# Altium schematic sheet Probe.SchDoc
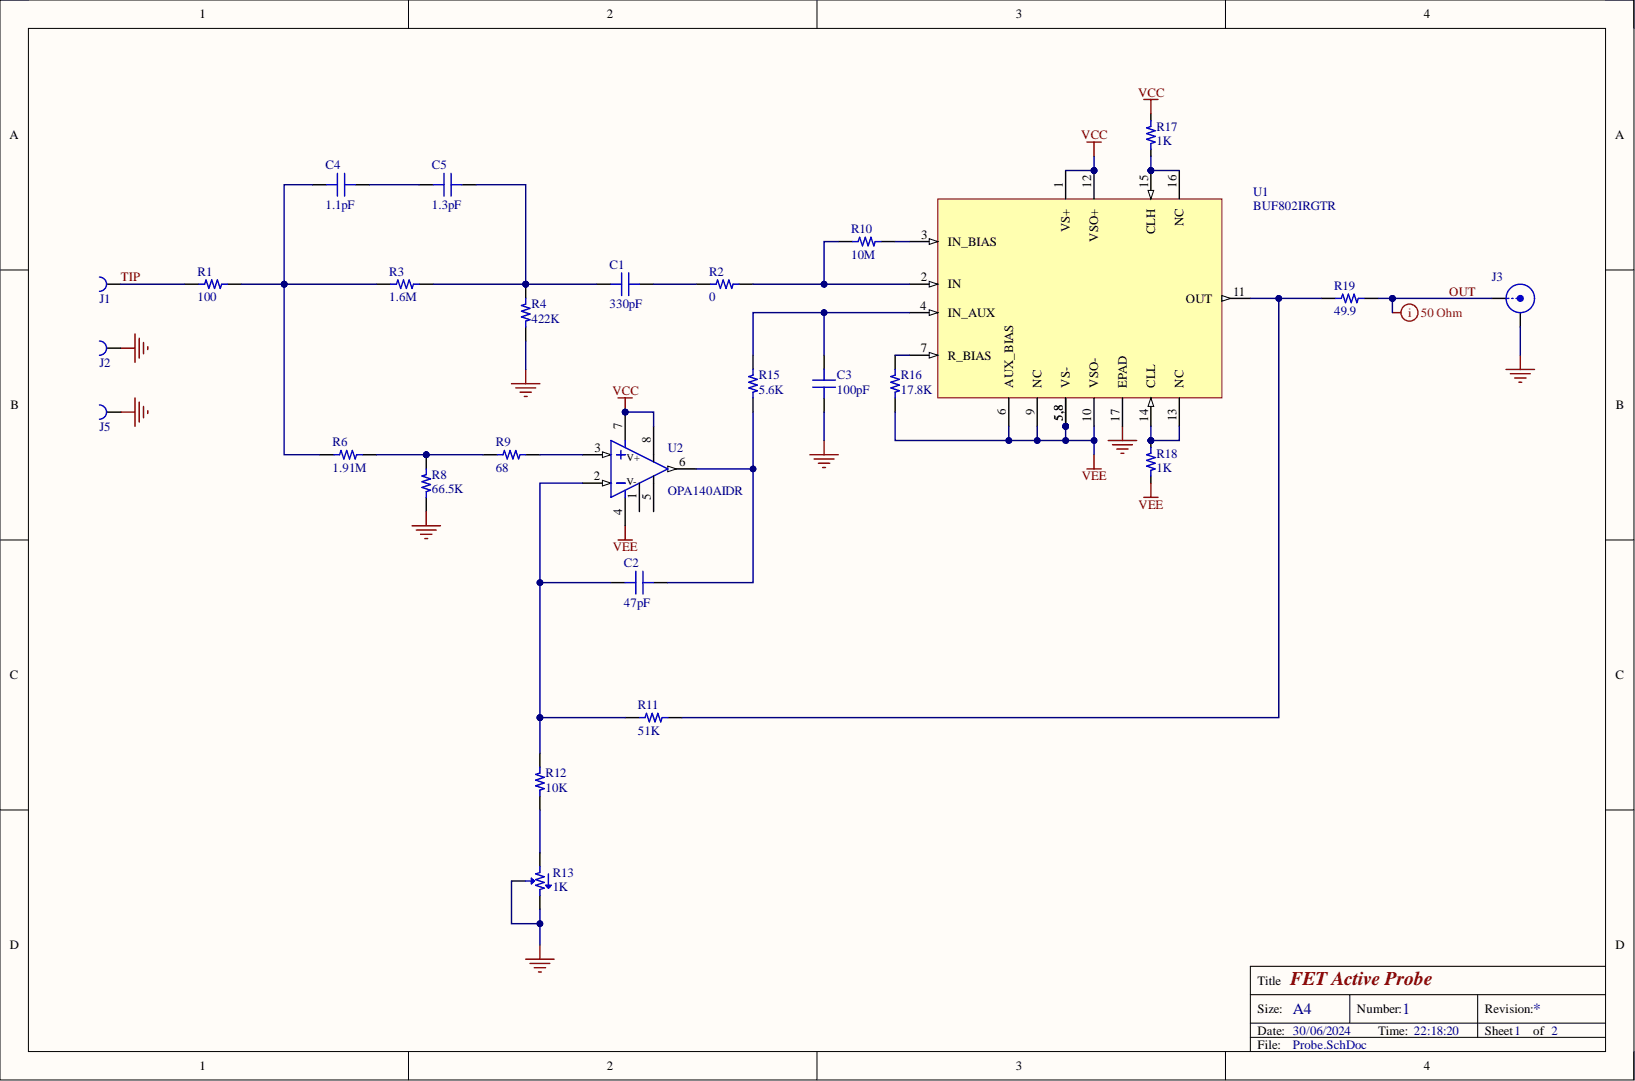
[diagram p0: Probe.SchDoc]

## Parts
C1  330pF  [CAP 0402/1005]
C2  47pF  [CAP 0402/1005]
C3  100pF  [CAP 0402/1005]
C4  1.1pF  [CAP 0402/1005 RF]
C5  1.3pF  [CAP 0402/1005 RF]
J1  0339-0-15-01-15-27-10-0  [MILL-MAX 0339]  (value not drawn)
J2  0339-0-15-01-15-27-10-0  [MILL-MAX 0339]  (value not drawn)
J3  CONN SMA JACK EDGE MNT  [60312202114513]  (value not drawn)
J5  0339-0-15-01-15-27-10-0  [MILL-MAX 0339]  (value not drawn)
R1  100  [RES 0402/1005]
R2  0  [RES 0402/1005]
R3  1.6M  [RES 0402/1005]
R4  422K  [RES 0402/1005]
R6  1.91M  [RES 0402/1005]
R8  66.5K  [RES 0402/1005]
R9  68  [RES 0402/1005]
R10  10M  [RES 0402/1005]
R11  51K  [RES 0402/1005]
R12  10K  [RES 0402/1005]
R13  1K  [BOURNS 3224W]
R15  5.6K  [RES 0402/1005]
R16  17.8K  [RES 0402/1005]
R17  1K  [RES 0402/1005]
R18  1K  [RES 0402/1005]
R19  49.9  [RES 0402/1005]
U1  BUF802IRGTR  [QFN50P305X305X100_HS-17N]
U2  OPA140AIDR  [TI SOIC-8 D]

## Nets
GND: C3.2 J2.1 J3.2 J5.1 R4.2 R8.2 R13.2 R13.3 U1.17(EPAD)
VCC: R17.2 U1.1(VS+) U1.12(VSO+) U2.7 U2.8
VEE: R16.2 R18.2 U1.5(VS-) U1.6(AUX_BIAS) U1.8 U1.9(NC) U1.10(VSO-) U2.4
OUT: J3.1 R19.2
TIP: J1.1 R1.1
NetC1_1: C1.1 C5.2 R3.2 R4.1
NetC1_2: C1.2 R2.1
NetC2_1: C2.1 R11.1 R12.1 U2.2(V-)
NetC2_2: C2.2 R15.2 U2.6
NetC3_1: C3.1 R15.1 U1.4(IN_AUX)
NetC4_1: C4.1 R1.2 R3.1 R6.1
NetC4_2: C4.2 C5.1
NetR2_2: R2.2 R10.1 U1.2(IN)
NetR6_2: R6.2 R8.1 R9.1
NetR9_2: R9.2 U2.3
NetR10_2: R10.2 U1.3(IN_BIAS)
NetR11_2: R11.2 R19.1 U1.11(OUT)
NetR12_2: R12.2 R13.1
NetR16_1: R16.1 U1.7(R_BIAS)
NetR17_1: R17.1 U1.15(CLH) U1.16(NC)
NetR18_1: R18.1 U1.13(NC) U1.14(CLL)

Not connected: U2 1(V+) 5

## Library data of the parts
C1 = CM0293: CAP CER 330PF 50V NP0 0402; Manufacturer: Murata; Part Number: GRM1555C1H331JA01D; Database Table Name: Capacitor - Murata GRM; TemperatureCoefficient: C0G, NP0; TemperatureRange: -55C ~ 125C; Tolerance: 5%; Component Kind: Standard; ComponentLink1Description: Datasheet; ComponentLink1URL: http://www.murata.com/~/media/webrenewal/support/library/catalog/products/capacitor/mlcc/c02e.ashx; ComponentLink2Description: Digi-Key listing; ComponentLink2URL: http://digikey.com/product-detail/en/GRM1555C1H331JA01D/490-1295-2-ND/587206; Device Package: 0402 (1005 Metric); PartId: CM0293; Price: 0.009; Supplier 1: Digi-Key; Supplier Part Number 1: 490-1295-2-ND; Type: -; Value: 330pF; Voltage: 50V; Library Name: Mengsklib.DbLib
C2 = CM0224: CAP CER 47PF 50V NP0 0402; Manufacturer: Murata; Part Number: GRM1555C1H470FA01D; Database Table Name: Capacitor - Murata GRM; TemperatureCoefficient: C0G, NP0; TemperatureRange: -55C ~ 125C; Tolerance: 1%; Component Kind: Standard; ComponentLink1Description: Datasheet; ComponentLink1URL: http://www.murata.com/~/media/webrenewal/support/library/catalog/products/capacitor/mlcc/c02e.ashx; ComponentLink2Description: Digi-Key listing; ComponentLink2URL: http://digikey.com/product-detail/en/GRM1555C1H470FA01D/490-6241-2-ND/3693991; Device Package: 0402 (1005 Metric); PartId: CM0224; Price: 0.0133; Supplier 1: Digi-Key; Supplier Part Number 1: 490-6241-2-ND; Type: -; Value: 47pF; Voltage: 50V; Library Name: Mengsklib.DbLib
C3 = CM0248: CAP CER 100PF 50V NP0 0402; Manufacturer: Murata; Part Number: GRM1555C1H101FA01D; Database Table Name: Capacitor - Murata GRM; TemperatureCoefficient: C0G, NP0; TemperatureRange: -55C ~ 125C; Tolerance: 1%; Component Kind: Standard; ComponentLink1Description: Datasheet; ComponentLink1URL: http://www.murata.com/~/media/webrenewal/support/library/catalog/products/capacitor/mlcc/c02e.pdf; ComponentLink2Description: Digi-Key Listing; ComponentLink2URL: https://www.digikey.com/product-detail/en/murata-electronics-north-america/GRM1555C1H101FA01D/490-8180-2-ND/3693919; Device Package: 0402 (1005 Metric); PartId: CM0248; Price: 0.0092; Supplier 1: Digi-Key; Supplier Part Number 1: 490-8180-2-ND; Value: 100pF; Voltage: 50V; Library Name: Mengsklib.DbLib
C4 = CR1657: CAP CER 1.1PF +-0.05PF 50V NP0 0201; Manufacturer: Murata; Part Number: GJM1555C1H1R1WB01D; Database Table Name: Capacitor - Murata RF; TemperatureCoefficient: C0G, NP0; TemperatureRange: -55C ~ 125C; Tolerance: 0.05pF; Type: High Q, Low Loss; Component Kind: Standard; ComponentLink1Description: Datasheet; ComponentLink1URL: https://www.murata.com/en-eu/products/capacitor/ceramiccapacitor/overview/lineup/smd/gjm; Device Package: 0402 (1005 Metric); PartId: CR1657; Price: 0.02; Supplier 1: Mouser; Supplier Part Number 1: 81-GJM1555C1H1R1WB01D; Value: 1.1pF; Voltage: 50V; Library Name: Mengsklib.DbLib
C5 = CR1663: CAP CER 1.3PF +-0.05PF 50V NP0 0201; Manufacturer: Murata; Part Number: GJM1555C1H1R3WB01D; Database Table Name: Capacitor - Murata RF; TemperatureCoefficient: C0G, NP0; TemperatureRange: -55C ~ 125C; Tolerance: 0.05pF; Type: High Q, Low Loss; Component Kind: Standard; ComponentLink1Description: Datasheet; ComponentLink1URL: https://www.murata.com/en-eu/products/capacitor/ceramiccapacitor/overview/lineup/smd/gjm; Device Package: 0402 (1005 Metric); PartId: CR1663; Price: 0.02; Supplier 1: Mouser; Supplier Part Number 1: 81-GJM1555C1H1R3WB01D; Value: 1.3pF; Voltage: 50V; Library Name: Mengsklib.DbLib
J1 J2 J5 = 0339-0-15-01-15-27-10-0: CONN PIN RCPT; Manufacturer: Mill-Max; Component Kind: Standard; ComponentLink1Description: https://www.mill-max.com/assets/pdfs/172.pdf?src-supplier=Digi-Key; ComponentLink1URL: https://www.mill-max.com/assets/pdfs/172.pdf?src-supplier=Digi-Key; ComponentLink2Description: https://datasheet.octopart.com/0339-0-15-01-15-27-10-0-Mill-Max-datasheet-26446830.pdf?src-supplier=Future+Electronics; ComponentLink2URL: https://datasheet.octopart.com/0339-0-15-01-15-27-10-0-Mill-Max-datasheet-26446830.pdf?src-supplier=Future+Electronics; ComponentLink3Description: http://www.mouser.com/ds/2/273/172M-276194.pdf?src-supplier=Mouser; ComponentLink3URL: http://www.mouser.com/ds/2/273/172M-276194.pdf?src-supplier=Mouser; ComponentLink4Description: https://datasheet.octopart.com/0339-0-15-01-15-27-10-0-Mill-Max-datasheet-155663258.pdf?src-supplier=Sager+Electronics; ComponentLink4URL: https://datasheet.octopart.com/0339-0-15-01-15-27-10-0-Mill-Max-datasheet-155663258.pdf?src-supplier=Sager+Electronics; Contact Material: Copper; Contact Plating: Gold; Library Name: Connectors.SchLib; Max Operating Temperature: 125°C; Min Operating Temperature: -55°C; Packaging: Bulk; Part Number: 0339-0-15-01-15-27-10-0; Pricing 1: 1=1.42, 10=1.234, 25=1.1812, 50=1.155, 100=1.1025, 250=0.99748, 500=0.91874, 1000=0.7875, 2500=0.735 (USD); RoHS Compliant: No; Series: 0339; Stock 1: 989; Supplier 1: Digi-Key; Supplier 2: Mouser; Supplier Part Number 1: 0339-0-15-01-15-27-10-0-ND; Supplier Part Number 2: 575-0339015011527100; Termination: Solder
J3 = CRF016: WR-SMA Connector, PCB End Launch Jack, 6.35SQ Flange Flat Tab 1.6mm, DC~18GHz, 50Ohm; Manufacturer: Wurth; Database Table Name: Connector - RF; Component Kind: Standard; ComponentLink1Description: Datasheet; ComponentLink1URL: https://www.we-online.com/redexpert/spec/603122021; Connector Type: SMA Jack; Impedance: 50Ohm; Mounting Technology: End Launch; Operating Temperature: -65C ~ 165C; Orientation: Straight; Part Number: 60312202114513; PartId: CRF016; Supplier 1: Mouser; Supplier 2: Digi-Key; Supplier Part Number 1: 710-60312202114513; Supplier Part Number 2: 732-13844-ND; Library Name: Mengsklib.DbLib
R1 = RC1250: RES SMD 100 OHM 1% 1/16W 0402; Manufacturer: Yageo; Part Number: RC0402FR-07100RL; Database Table Name: Resistor - Yageo RC; PowerRating: 0.0625W, 1/16W; TemperatureCoefficient: 100ppm/C; TemperatureRange: -55C ~ 155C; Component Kind: Standard; ComponentLink1Description: Datasheet; ComponentLink1URL: http://www.yageo.com/NewPortal/yageodocoutput?fileName=/pdf/R-Chip/PYu-RC_Group_51_RoHS_L_9.pdf; Device Package: 0402 (1005 Metric); PartId: RC1250; Price: 0.0043; Supplier 1: Mouser; Supplier Part Number 1: 603-RC0402FR-07100RL; Tolerance: 1%; Type: Thick Film; Value: 100; Library Name: Mengsklib.DbLib
R2 = RC0971: RES SMD 0 OHM 5% 1/16W 0402; Manufacturer: Yageo; Part Number: RC0402JR-070RL; Database Table Name: Resistor - Yageo RC; PowerRating: 0.0625W, 1/16W; TemperatureCoefficient: 100ppm/C; TemperatureRange: -55C ~ 155C; Component Kind: Standard; ComponentLink1Description: Datasheet; ComponentLink1URL: http://www.yageo.com/NewPortal/yageodocoutput?fileName=/pdf/R-Chip/PYu-RC_Group_51_RoHS_L_9.pdf; Device Package: 0402 (1005 Metric); PartId: RC0971; Price: 0.0043; Supplier 1: Mouser; Supplier Part Number 1: 603-RC0402JR-070RL; Tolerance: 5%; Type: Thick Film; Value: 0; Library Name: Mengsklib.DbLib
R3 = RC1837: RES SMD 1.6M OHM 1% 1/16W 0402; Manufacturer: Yageo; Part Number: RC0402FR-071M6L; Database Table Name: Resistor - Yageo RC; PowerRating: 0.0625W, 1/16W; TemperatureCoefficient: 100ppm/C; TemperatureRange: -55C ~ 155C; Component Kind: Standard; ComponentLink1Description: Datasheet; ComponentLink1URL: http://www.yageo.com/NewPortal/yageodocoutput?fileName=/pdf/R-Chip/PYu-RC_Group_51_RoHS_L_9.pdf; Device Package: 0402 (1005 Metric); PartId: RC1837; Price: 0.0043; Supplier 1: Mouser; Supplier Part Number 1: 603-RC0402FR-071M6L; Tolerance: 1%; Type: Thick Film; Value: 1.6M; Library Name: Mengsklib.DbLib
R4 = RC1757: RES SMD 422K OHM 1% 1/16W 0402; Manufacturer: Yageo; Part Number: RC0402FR-07422KL; Database Table Name: Resistor - Yageo RC; PowerRating: 0.0625W, 1/16W; TemperatureCoefficient: 100ppm/C; TemperatureRange: -55C ~ 155C; Component Kind: Standard; ComponentLink1Description: Datasheet; ComponentLink1URL: http://www.yageo.com/NewPortal/yageodocoutput?fileName=/pdf/R-Chip/PYu-RC_Group_51_RoHS_L_9.pdf; Device Package: 0402 (1005 Metric); PartId: RC1757; Price: 0.0043; Supplier 1: Mouser; Supplier Part Number 1: 603-RC0402FR-07422KL; Tolerance: 1%; Type: Thick Film; Value: 422K; Library Name: Mengsklib.DbLib
R6 = RC1847: RES SMD 1.91M OHM 1% 1/16W 0402; Manufacturer: Yageo; Part Number: RC0402FR-071M91L; Database Table Name: Resistor - Yageo RC; PowerRating: 0.0625W, 1/16W; TemperatureCoefficient: 100ppm/C; TemperatureRange: -55C ~ 155C; Component Kind: Standard; ComponentLink1Description: Datasheet; ComponentLink1URL: http://www.yageo.com/NewPortal/yageodocoutput?fileName=/pdf/R-Chip/PYu-RC_Group_51_RoHS_L_9.pdf; Device Package: 0402 (1005 Metric); PartId: RC1847; Price: 0.0043; Supplier 1: Mouser; Supplier Part Number 1: 603-RC0402FR-071M91L; Tolerance: 1%; Type: Thick Film; Value: 1.91M; Library Name: Mengsklib.DbLib
R8 = RC1647: RES SMD 66.5K OHM 1% 1/16W 0402; Manufacturer: Yageo; Part Number: RC0402FR-0766K5L; Database Table Name: Resistor - Yageo RC; PowerRating: 0.0625W, 1/16W; TemperatureCoefficient: 100ppm/C; TemperatureRange: -55C ~ 155C; Component Kind: Standard; ComponentLink1Description: Datasheet; ComponentLink1URL: http://www.yageo.com/NewPortal/yageodocoutput?fileName=/pdf/R-Chip/PYu-RC_Group_51_RoHS_L_9.pdf; Device Package: 0402 (1005 Metric); PartId: RC1647; Price: 0.0043; Supplier 1: Mouser; Supplier Part Number 1: 603-RC0402FR-0766K5L; Tolerance: 1%; Type: Thick Film; Value: 66.5K; Library Name: Mengsklib.DbLib
R9 = RC1227: RES SMD 68 OHM 1% 1/16W 0402; Manufacturer: Yageo; Part Number: RC0402FR-0768RL; Database Table Name: Resistor - Yageo RC; PowerRating: 0.0625W, 1/16W; TemperatureCoefficient: 100ppm/C; TemperatureRange: -55C ~ 155C; Component Kind: Standard; ComponentLink1Description: Datasheet; ComponentLink1URL: http://www.yageo.com/NewPortal/yageodocoutput?fileName=/pdf/R-Chip/PYu-RC_Group_51_RoHS_L_9.pdf; Device Package: 0402 (1005 Metric); PartId: RC1227; Price: 0.0043; Supplier 1: Mouser; Supplier Part Number 1: 603-RC0402FR-0768RL; Tolerance: 1%; Type: Thick Film; Value: 68; Library Name: Mengsklib.DbLib
R10 = RC1949: RES SMD 10M OHM 1% 1/16W 0402; Manufacturer: Yageo; Part Number: RC0402FR-0710ML; Database Table Name: Resistor - Yageo RC; PowerRating: 0.0625W, 1/16W; TemperatureCoefficient: 100ppm/C; TemperatureRange: -55C ~ 155C; Component Kind: Standard; ComponentLink1Description: Datasheet; ComponentLink1URL: http://www.yageo.com/NewPortal/yageodocoutput?fileName=/pdf/R-Chip/PYu-RC_Group_51_RoHS_L_9.pdf; Device Package: 0402 (1005 Metric); PartId: RC1949; Price: 0.0043; Supplier 1: Mouser; Supplier Part Number 1: 603-RC0402FR-0710ML; Tolerance: 1%; Type: Thick Film; Value: 10M; Library Name: Mengsklib.DbLib
R11 = RC1630: RES SMD 51K OHM 1% 1/16W 0402; Manufacturer: Yageo; Part Number: RC0402FR-0751KL; Database Table Name: Resistor - Yageo RC; PowerRating: 0.0625W, 1/16W; TemperatureCoefficient: 100ppm/C; TemperatureRange: -55C ~ 155C; Component Kind: Standard; ComponentLink1Description: Datasheet; ComponentLink1URL: http://www.yageo.com/NewPortal/yageodocoutput?fileName=/pdf/R-Chip/PYu-RC_Group_51_RoHS_L_9.pdf; Device Package: 0402 (1005 Metric); PartId: RC1630; Price: 0.0043; Supplier 1: Mouser; Supplier Part Number 1: 603-RC0402FR-0751KL; Tolerance: 1%; Type: Thick Film; Value: 51K; Library Name: Mengsklib.DbLib
R12 = RC1531: RES SMD 10K OHM 1% 1/16W 0402; Manufacturer: Yageo; Part Number: RC0402FR-0710KL; Database Table Name: Resistor - Yageo RC; PowerRating: 0.0625W, 1/16W; TemperatureCoefficient: 100ppm/C; TemperatureRange: -55C ~ 155C; Component Kind: Standard; ComponentLink1Description: Datasheet; ComponentLink1URL: http://www.yageo.com/NewPortal/yageodocoutput?fileName=/pdf/R-Chip/PYu-RC_Group_51_RoHS_L_9.pdf; Device Package: 0402 (1005 Metric); PartId: RC1531; Price: 0.0043; Supplier 1: Mouser; Supplier Part Number 1: 603-RC0402FR-0710KL; Tolerance: 1%; Type: Thick Film; Value: 10K; Library Name: Mengsklib.DbLib
R13 = PBT084: TRIMMER 1K OHM 0.25W J LEAD TOP; Manufacturer: Bourns; Part Number: 3224W-1-102E; Adjustment Type: Top Adjustment; Database Table Name: Potentiometer - Bourns Trim; Number of Turns: 12; PowerRating: 0.25W, 1/4W; Resistive Material: Cermet; TemperatureCoefficient: 100ppm/C; TemperatureRange: -65C ~ 150C; Tolerance: 10%; Component Kind: Standard; ComponentLink1Description: Datasheet; ComponentLink1URL: https://www.bourns.com/docs/Product-Datasheets/3224.pdf; Device Package: Rectangular - 0.189" x 0.138" Face x 0.209" H (4.80mm x 3.50mm x 5.30mm); Mounting Type: Surface Mount; PartId: PBT084; Price: 3.278; Supplier 1: Mouser; Supplier 2: Digi-Key; Supplier Part Number 1: 652-3224W-1-102E; Supplier Part Number 2: 3224W-1-102ETR-ND; Value: 1K; Library Name: Mengsklib.DbLib
R15 = RC1496: RES SMD 5.6K OHM 1% 1/16W 0402; Manufacturer: Yageo; Part Number: RC0402FR-075K6L; Database Table Name: Resistor - Yageo RC; PowerRating: 0.0625W, 1/16W; TemperatureCoefficient: 100ppm/C; TemperatureRange: -55C ~ 155C; Component Kind: Standard; ComponentLink1Description: Datasheet; ComponentLink1URL: http://www.yageo.com/NewPortal/yageodocoutput?fileName=/pdf/R-Chip/PYu-RC_Group_51_RoHS_L_9.pdf; Device Package: 0402 (1005 Metric); PartId: RC1496; Price: 0.0043; Supplier 1: Mouser; Supplier Part Number 1: 603-RC0402FR-075K6L; Tolerance: 1%; Type: Thick Film; Value: 5.6K; Library Name: Mengsklib.DbLib
R16 = RC1562: RES SMD 17.8K OHM 1% 1/16W 0402; Manufacturer: Yageo; Part Number: RC0402FR-0717K8L; Database Table Name: Resistor - Yageo RC; PowerRating: 0.0625W, 1/16W; TemperatureCoefficient: 100ppm/C; TemperatureRange: -55C ~ 155C; Component Kind: Standard; ComponentLink1Description: Datasheet; ComponentLink1URL: http://www.yageo.com/NewPortal/yageodocoutput?fileName=/pdf/R-Chip/PYu-RC_Group_51_RoHS_L_9.pdf; Device Package: 0402 (1005 Metric); PartId: RC1562; Price: 0.0043; Supplier 1: Mouser; Supplier Part Number 1: 603-RC0402FR-0717K8L; Tolerance: 1%; Type: Thick Film; Value: 17.8K; Library Name: Mengsklib.DbLib
R17 R18 = RC1389: RES SMD 1K OHM 1% 1/16W 0402; Manufacturer: Yageo; Part Number: RC0402FR-071KL; Database Table Name: Resistor - Yageo RC; PowerRating: 0.0625W, 1/16W; TemperatureCoefficient: 100ppm/C; TemperatureRange: -55C ~ 155C; Component Kind: Standard; ComponentLink1Description: Datasheet; ComponentLink1URL: http://www.yageo.com/NewPortal/yageodocoutput?fileName=/pdf/R-Chip/PYu-RC_Group_51_RoHS_L_9.pdf; Device Package: 0402 (1005 Metric); PartId: RC1389; Price: 0.0043; Supplier 1: Mouser; Supplier Part Number 1: 603-RC0402FR-071KL; Tolerance: 1%; Type: Thick Film; Value: 1K; Library Name: Mengsklib.DbLib
R19 = RC1206: RES SMD 49.9 OHM 1% 1/16W 0402; Manufacturer: Yageo; Part Number: RC0402FR-0749R9L; Database Table Name: Resistor - Yageo RC; PowerRating: 0.0625W, 1/16W; TemperatureCoefficient: 100ppm/C; TemperatureRange: -55C ~ 155C; Component Kind: Standard; ComponentLink1Description: Datasheet; ComponentLink1URL: http://www.yageo.com/NewPortal/yageodocoutput?fileName=/pdf/R-Chip/PYu-RC_Group_51_RoHS_L_9.pdf; Device Package: 0402 (1005 Metric); PartId: RC1206; Price: 0.0043; Supplier 1: Mouser; Supplier Part Number 1: 603-RC0402FR-0749R9L; Tolerance: 1%; Type: Thick Film; Value: 49.9; Library Name: Mengsklib.DbLib
U1 = UO0077: IC AMP WIDE BANDWIDTH 16VQFN; Manufacturer: TI; Database Table Name: IC - Amplifier; Component Kind: Standard; ComponentLink1Description: Datasheet; ComponentLink1URL: https://www.ti.com/lit/ds/symlink/buf802.pdf; Device Package: 16-VQFN; Operating Temperature: -40C ~ 125C; Part Number: BUF802IRGTR; PartId: UO0077; Price: 6; Supplier 1: Digi-Key; Supplier 2: Mouser; Supplier Part Number 1: 296-BUF802IRGTRCT-ND; Supplier Part Number 2: 595-BUF802IRGTR; Type: OPAMP; Library Name: Mengsklib.DbLib
U2 = UO0076: IC OPAMP JFET 1CIRC 8SOIC; Manufacturer: TI; Database Table Name: IC - Amplifier; Component Kind: Standard; ComponentLink1Description: Datasheet; ComponentLink1URL: https://www.ti.com/lit/ds/symlink/opa4140.pdf; Device Package: 8-SOIC; Operating Temperature: -40C ~ 125C; Part Number: OPA140AIDR; PartId: UO0076; Price: 4; Supplier 1: Digi-Key; Supplier 2: Mouser; Supplier Part Number 1: 296-43606-2-ND; Supplier Part Number 2: 595-OPA140AIDR; Type: OPAMP; Library Name: Mengsklib.DbLib
